# Altium schematic sheet S7CAS-PLEIADES-CARTE-MERE_P01_FPGA-ALIM.SchDoc
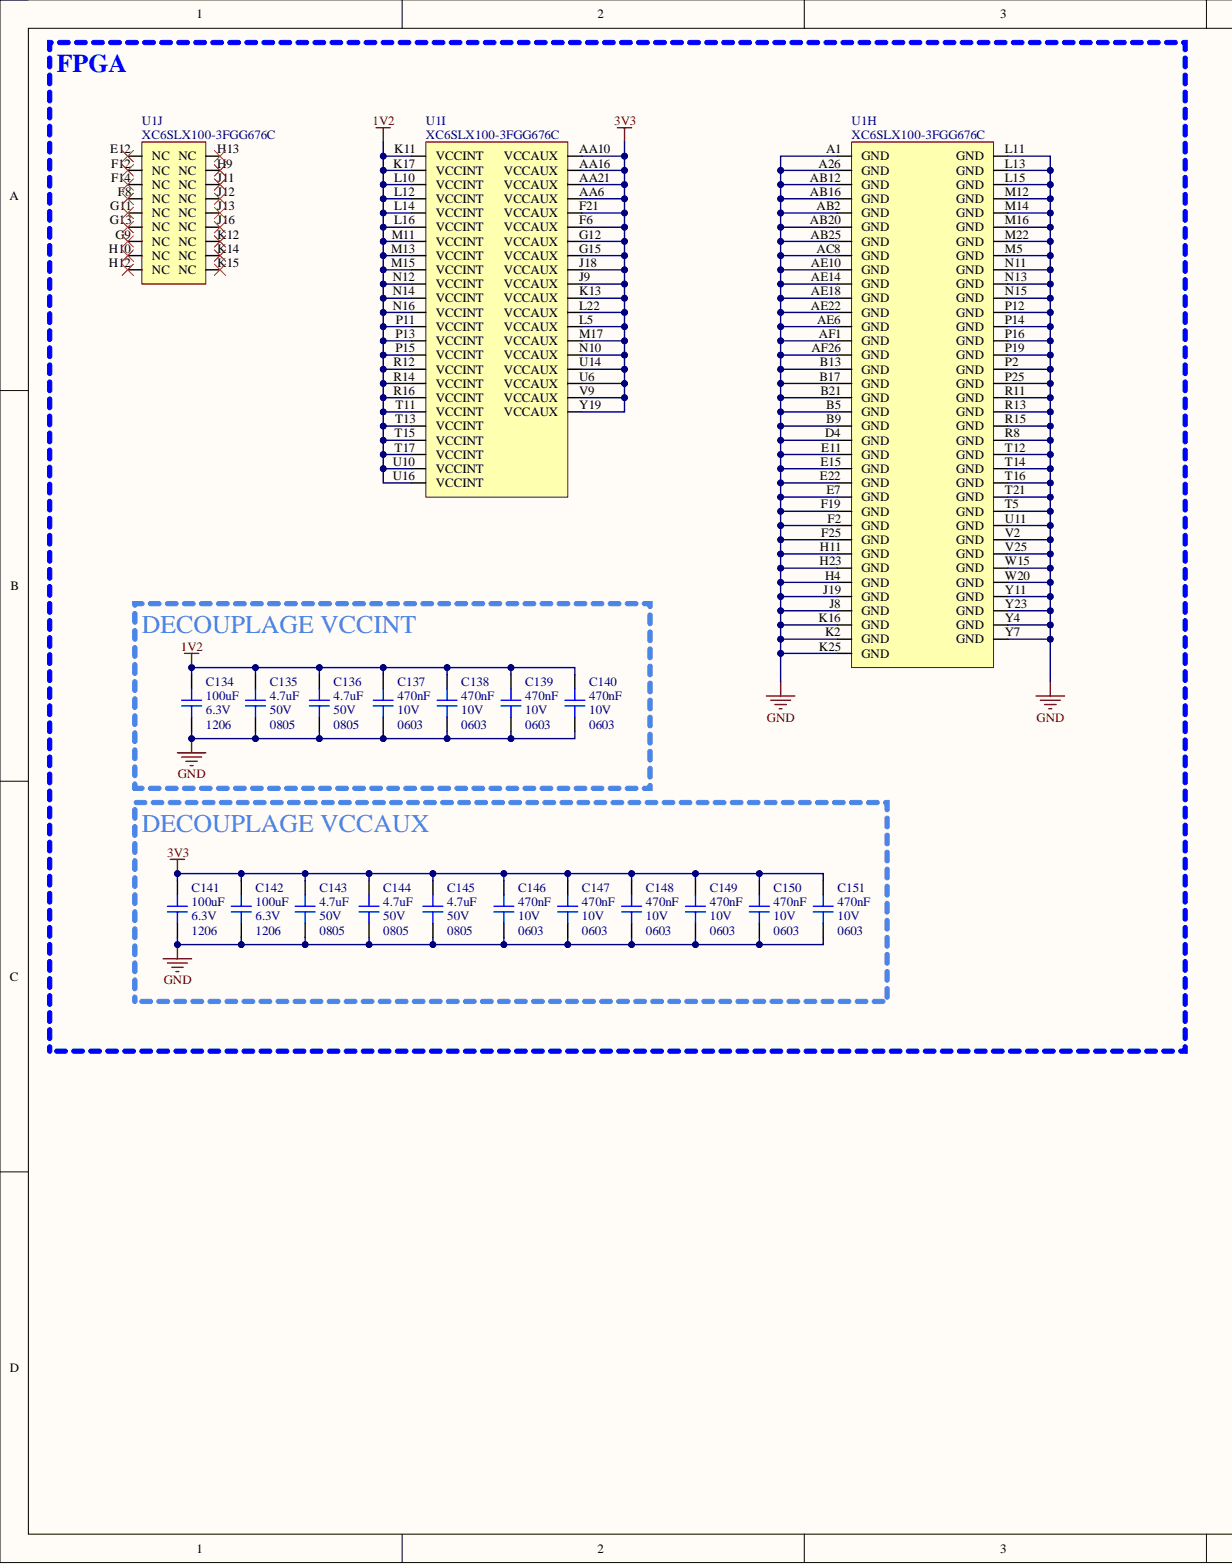
[diagram p0: S7CAS-PLEIADES-CARTE-MERE_P01_FPGA-ALIM.SchDoc, left]
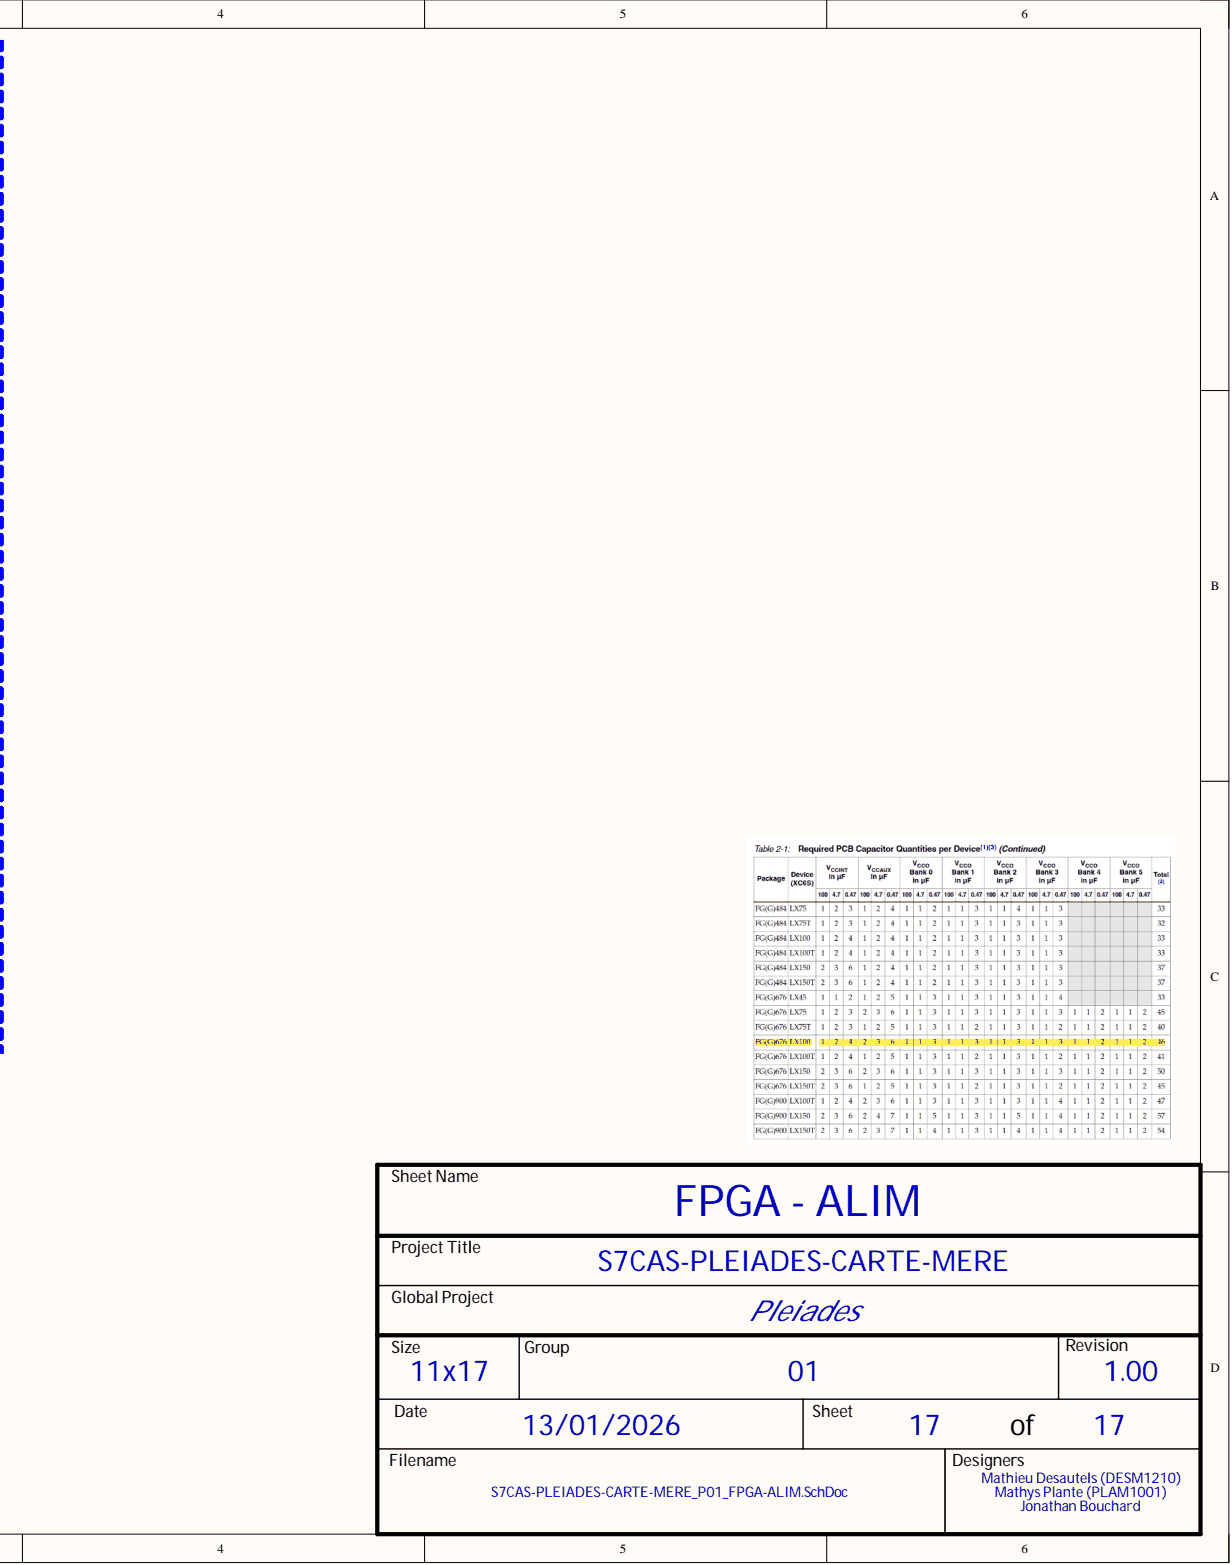
[diagram p1: S7CAS-PLEIADES-CARTE-MERE_P01_FPGA-ALIM.SchDoc, right]

## Parts
C134  value 100uF  Package: 1206  Voltage: 6.3V  [CAP_1206]  (hidden comment: CAP - 1206)
C135  value 4.7uF  Package: 0805  Voltage: 50V  [CAP_0805]  (hidden comment: CAP - 0805)
C136  value 4.7uF  Package: 0805  Voltage: 50V  [CAP_0805]  (hidden comment: CAP - 0805)
C137  value 470nF  Package: 0603  Voltage: 10V  [CAP_0603]  (hidden comment: CAP - 0603)
C138  value 470nF  Package: 0603  Voltage: 10V  [CAP_0603]  (hidden comment: CAP - 0603)
C139  value 470nF  Package: 0603  Voltage: 10V  [CAP_0603]  (hidden comment: CAP - 0603)
C140  value 470nF  Package: 0603  Voltage: 10V  [CAP_0603]  (hidden comment: CAP - 0603)
C141  value 100uF  Package: 1206  Voltage: 6.3V  [CAP_1206]  (hidden comment: CAP - 1206)
C142  value 100uF  Package: 1206  Voltage: 6.3V  [CAP_1206]  (hidden comment: CAP - 1206)
C143  value 4.7uF  Package: 0805  Voltage: 50V  [CAP_0805]  (hidden comment: CAP - 0805)
C144  value 4.7uF  Package: 0805  Voltage: 50V  [CAP_0805]  (hidden comment: CAP - 0805)
C145  value 4.7uF  Package: 0805  Voltage: 50V  [CAP_0805]  (hidden comment: CAP - 0805)
C146  value 470nF  Package: 0603  Voltage: 10V  [CAP_0603]  (hidden comment: CAP - 0603)
C147  value 470nF  Package: 0603  Voltage: 10V  [CAP_0603]  (hidden comment: CAP - 0603)
C148  value 470nF  Package: 0603  Voltage: 10V  [CAP_0603]  (hidden comment: CAP - 0603)
C149  value 470nF  Package: 0603  Voltage: 10V  [CAP_0603]  (hidden comment: CAP - 0603)
C150  value 470nF  Package: 0603  Voltage: 10V  [CAP_0603]  (hidden comment: CAP - 0603)
C151  value 470nF  Package: 0603  Voltage: 10V  [CAP_0603]  (hidden comment: CAP - 0603)
U1  XC6SLX100-3FGG676C  [FPGA_XILINX_FGG676]  (parts H,I,J)

## Nets
1V2: C134.2 C135.2 C136.2 C137.2 C138.2 C139.2 C140.2 U1.K11(VCCINT) U1.K17(VCCINT) U1.L10(VCCINT) U1.L12(VCCINT) U1.L14(VCCINT) U1.L16(VCCINT) U1.M11(VCCINT) U1.M13(VCCINT) U1.M15(VCCINT) U1.N12(VCCINT) U1.N14(VCCINT) U1.N16(VCCINT) U1.P11(VCCINT) U1.P13(VCCINT) U1.P15(VCCINT) U1.R12(VCCINT) U1.R14(VCCINT) U1.R16(VCCINT) U1.T11(VCCINT) U1.T13(VCCINT) U1.T15(VCCINT) U1.T17(VCCINT) U1.U10(VCCINT) U1.U16(VCCINT)
3V3: C141.2 C142.2 C143.2 C144.2 C145.2 C146.2 C147.2 C148.2 C149.2 C150.2 C151.2 U1.AA6(VCCAUX) U1.AA10(VCCAUX) U1.AA16(VCCAUX) U1.AA21(VCCAUX) U1.F6(VCCAUX) U1.F21(VCCAUX) U1.G12(VCCAUX) U1.G15(VCCAUX) U1.J9(VCCAUX) U1.J18(VCCAUX) U1.K13(VCCAUX) U1.L5(VCCAUX) U1.L22(VCCAUX) U1.M17(VCCAUX) U1.N10(VCCAUX) U1.U6(VCCAUX) U1.U14(VCCAUX) U1.V9(VCCAUX) U1.Y19(VCCAUX)
GND: C134.1 C135.1 C136.1 C137.1 C138.1 C139.1 C140.1 C141.1 C142.1 C143.1 C144.1 C145.1 C146.1 C147.1 C148.1 C149.1 C150.1 C151.1 U1.A1(GND) U1.A26(GND) U1.AB2(GND) U1.AB12(GND) U1.AB16(GND) U1.AB20(GND) U1.AB25(GND) U1.AC8(GND) U1.AE6(GND) U1.AE10(GND) U1.AE14(GND) U1.AE18(GND) U1.AE22(GND) U1.AF1(GND) U1.AF26(GND) U1.B5(GND) U1.B9(GND) U1.B13(GND) U1.B17(GND) U1.B21(GND) U1.D4(GND) U1.E7(GND) U1.E11(GND) U1.E15(GND) U1.E22(GND) U1.F2(GND) U1.F19(GND) U1.F25(GND) U1.H4(GND) U1.H11(GND) U1.H23(GND) U1.J8(GND) U1.J19(GND) U1.K2(GND) U1.K16(GND) U1.K25(GND) U1.L11(GND) U1.L13(GND) U1.L15(GND) U1.M5(GND) U1.M12(GND) U1.M14(GND) U1.M16(GND) U1.M22(GND) U1.N11(GND) U1.N13(GND) U1.N15(GND) U1.P2(GND) U1.P12(GND) U1.P14(GND) U1.P16(GND) U1.P19(GND) U1.P25(GND) U1.R8(GND) U1.R11(GND) U1.R13(GND) U1.R15(GND) U1.T5(GND) U1.T12(GND) U1.T14(GND) U1.T16(GND) U1.T21(GND) U1.U11(GND) U1.V2(GND) U1.V25(GND) U1.W15(GND) U1.W20(GND) U1.Y4(GND) U1.Y7(GND) U1.Y11(GND) U1.Y23(GND)

Not connected: U1 E12(NC) F8(NC) F12(NC) F14(NC) G9(NC) G11(NC) G13(NC) H9(NC) H10(NC) H12(NC) H13(NC) J11(NC) J12(NC) J13(NC) J16(NC) K12(NC) K14(NC) K15(NC)

## Library data of the parts
C134 C141 C142 = CAP - 1206: 100 µF ±20% 6.3V Ceramic Capacitor X6T 1206 (3216 Metric); Mfg Part Number: GRM31CD80J107MEA8K; Mfg: Murata Electronics; Component Kind: Standard; Library Name: s7cas_lib2023.intlib; Precision: 20%; CreatedBy: Jonathan Bouchard
C135 C136 C143-C145 = CAP - 0805: 4.7 µF ±10% 50V Ceramic Capacitor X5R 0805 (2012 Metric); Mfg Part Number: GRT21BR61H475KE13L; Mfg: Murata Electronics; Component Kind: Standard; Library Name: s7cas_lib2023.intlib; Precision: 10%; CreatedBy: Jonathan Bouchard
C137-C140 C146-C151 = CAP - 0603: Mfg Part Number: C0603X474K8RAC; Precision: 10%; Component Kind: Standard; Description: CAP - 0603; Library Name: s7cas_lib2023.intlib; CreatedBy: Jonathan Bouchard
U1 = FPGA_XC6SLX100-3FGG676C: FPGA - XILINX SPARTAN 6 480 I/O - BGA-676; Voltage: 1.14 V ~ 1.26 V; Component Kind: Standard; HelpURL: https://www.xilinx.com/support/documentation/data_sheets/ds162.pdf; Library Name: s7cas_lib2023.intlib; Mfg: Xilinx; Mfg Part Number: XC6SLX100-3FGG676C; Package: BGA-676; Part Number #1: XC6SLX100-3FGG676C; Supplier 1: Digikey; Supplier Part Number 1: 122-1760-ND; Temperature: 0°C ~ 85°C (TJ); CreatedBy: Jonathan Bouchard
